# Altium schematic sheet Analog Out Amplifiers PWM Rev 5.SchDoc("Analog Out Amplifiers PWM Rev 5")
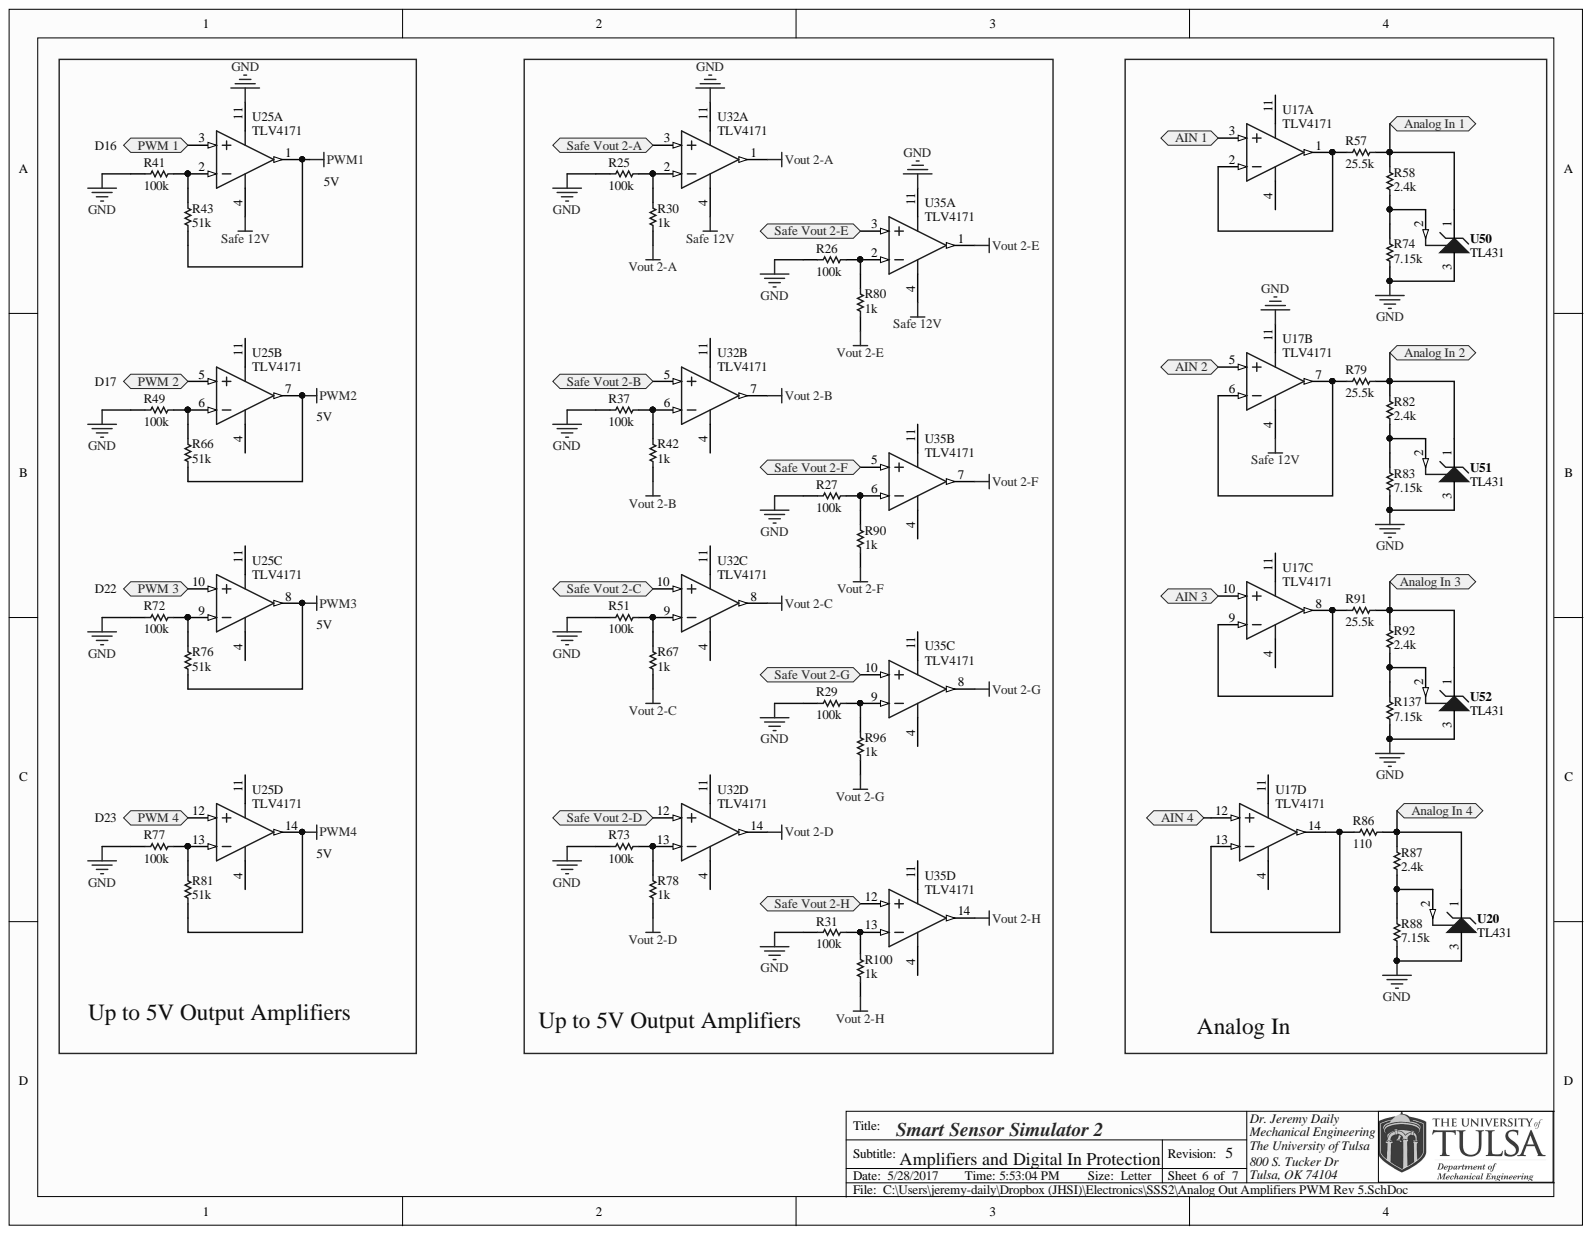
[diagram p0: Analog Out Amplifiers PWM Rev 5.SchDoc]

## Parts
R25  100k  [0603]
R26  100k  [0603]
R27  100k  [0603]
R29  100k  [0603]
R30  1k  [0603]
R31  100k  [0603]
R37  100k  [0603]
R41  100k  [0603]
R42  1k  [0603]
R43  51k  [0603]
R49  100k  [0603]
R51  100k  [0603]
R57  25.5k  [0603]
R58  2.4k  [0603]
R66  51k  [0603]
R67  1k  [0603]
R72  100k  [0603]
R73  100k  [0603]
R74  7.15k  [0603]
R76  51k  [0603]
R77  100k  [0603]
R78  1k  [0603]
R79  25.5k  [0603]
R80  1k  [0603]
R81  51k  [0603]
R82  2.4k  [0603]
R83  7.15k  [0603]
R86  110  [0603]
R87  2.4k  [0603]
R88  7.15k  [0603]
R90  1k  [0603]
R91  25.5k  [0603]
R92  2.4k  [0603]
R96  1k  [0603]
R100  1k  [0603]
R137  7.15k  [0603]
U17  TLV4171  [TI-PW14_M]  (parts A,B,C,D)
U20  TL431  [TI-DBZ3_N]
U25  TLV4171  [TI-PW14_M]  (parts A,B,C,D)
U32  TLV4171  [TI-PW14_M]  (parts A,B,C,D)
U35  TLV4171  [TI-PW14_M]  (parts A,B,C,D)
U50  TL431  [TI-DBZ3_N]
U51  TL431  [TI-DBZ3_N]
U52  TL431  [TI-DBZ3_N]

## Ports
AIN 1, AIN 2, AIN 3, AIN 4, ANALOG IN 1, ANALOG IN 2, ANALOG IN 3, ANALOG IN 4, PWM 1, PWM 2, PWM 3, PWM 4, SAFE VOUT 2-A, SAFE VOUT 2-B, SAFE VOUT 2-C, SAFE VOUT 2-D, SAFE VOUT 2-E, SAFE VOUT 2-F, SAFE VOUT 2-G, SAFE VOUT 2-H

## Nets
GND: R25.2 R26.2 R27.2 R29.2 R31.2 R37.2 R41.2 R49.2 R51.2 R72.2 R73.2 R74.1 R77.2 R83.1 R88.1 R137.1 U17.11 U20.3 U25.11 U32.11 U35.11 U50.3 U51.3 U52.3
PWM1: R43.2 U25.1
PWM2: R66.2 U25.7
PWM3: R76.2 U25.8
PWM4: R81.2 U25.14
SAFE 12V: U17.4 U25.4 U32.4 U35.4
VOUT 2-A: R30.2 U32.1
VOUT 2-B: R42.2 U32.7
VOUT 2-C: R67.2 U32.8
VOUT 2-D: R78.2 U32.14
VOUT 2-E: R80.2 U35.1
VOUT 2-F: R90.2 U35.7
VOUT 2-G: R96.2 U35.8
VOUT 2-H: R100.2 U35.14
AIN 1: U17.3 ; port AIN 1
AIN 2: U17.5 ; port AIN 2
AIN 3: U17.10 ; port AIN 3
AIN 4: U17.12 ; port AIN 4
PWM 1: U25.3 ; port PWM 1
PWM 2: U25.5 ; port PWM 2
PWM 3: U25.10 ; port PWM 3
PWM 4: U25.12 ; port PWM 4
NetR25_1: R25.1 R30.1 U32.2
NetR26_1: R26.1 R80.1 U35.2
NetR27_1: R27.1 R90.1 U35.6
NetR29_1: R29.1 R96.1 U35.9
NetR31_1: R31.1 R100.1 U35.13
NetR37_1: R37.1 R42.1 U32.6
NetR41_1: R41.1 R43.1 U25.2
NetR49_1: R49.1 R66.1 U25.6
NetR51_1: R51.1 R67.1 U32.9
NetR57_1: R57.1 U17.1 U17.2
NetR57_2: R57.2 R58.2 U50.1 ; port ANALOG IN 1
NetR58_1: R58.1 R74.2 U50.2
NetR72_1: R72.1 R76.1 U25.9
NetR73_1: R73.1 R78.1 U32.13
NetR77_1: R77.1 R81.1 U25.13
NetR79_1: R79.1 U17.6 U17.7
NetR79_2: R79.2 R82.2 U51.1 ; port ANALOG IN 2
NetR82_1: R82.1 R83.2 U51.2
NetR86_1: R86.1 U17.13 U17.14
NetR86_2: R86.2 R87.2 U20.1 ; port ANALOG IN 4
NetR87_1: R87.1 R88.2 U20.2
NetR91_1: R91.1 U17.8 U17.9
NetR91_2: R91.2 R92.2 U52.1 ; port ANALOG IN 3
NetR92_1: R92.1 R137.2 U52.2
NetU32_3: U32.3 ; port SAFE VOUT 2-A
NetU32_5: U32.5 ; port SAFE VOUT 2-B
NetU32_10: U32.10 ; port SAFE VOUT 2-C
NetU32_12: U32.12 ; port SAFE VOUT 2-D
NetU35_3: U35.3 ; port SAFE VOUT 2-E
NetU35_5: U35.5 ; port SAFE VOUT 2-F
NetU35_10: U35.10 ; port SAFE VOUT 2-G
NetU35_12: U35.12 ; port SAFE VOUT 2-H

## Library data of the parts
R25-R27 R29 R31 R37 R41 R49 R51 R72 R73 R77 = Chip Resistor: RES SMD 100K OHM 1% 1/10W 0603; Manufacturer: Panasonic Electronic Components; PartNumber: ERJ-3EKF1003V; Component Kind: Standard; Library Name: SSS Integrated Library.IntLib; Supplier 1: Digi-Key; Supplier Part Number 1: P100KHCT-ND
R30 R42 R67 R78 R80 R90 R96 R100 = Chip Resistor: RES SMD 1K OHM 1% 1/10W 0603; Manufacturer: Panasonic Electronic Components; PartNumber: ERJ-3EKF1001V; Component Kind: Standard; Library Name: SSS Schematic Library.SchLib; Supplier 1: Digi-Key; Supplier Part Number 1: P1.00KHCT-ND
R43 R66 R76 R81 = Chip Resistor: RES SMD 51K OHM 1% 1/10W 0603; Manufacturer: Panasonic Electronic Components; PartNumber: ERJ-3EKF5102V; Component Kind: Standard; Library Name: SSS Integrated Library.IntLib; Supplier 1: Digi-Key; Supplier Part Number 1: P51.0KHCT-ND
R57 R79 R91 = Chip Resistor: RES SMD 25.5K OHM 1% 1/10W 0603; Manufacturer: Panasonic Electronic Components; PartNumber: ERJ-3EKF2552V; Component Kind: Standard; Library Name: SSS Integrated Library.IntLib; Supplier 1: Digi-Key; Supplier Part Number 1: P25.5KHCT-ND
R58 R82 R87 R92 = Chip Resistor: RES SMD 2.4K OHM 1% 1/10W 0603; Manufacturer: Panasonic Electronic Components; PartNumber: ERJ-3EKF2401V; Component Kind: Standard; Library Name: SSS Integrated Library.IntLib; Supplier 1: Digi-Key; Supplier Part Number 1: P2.40KHCT-ND
R74 R83 R88 R137 = Chip Resistor: RES SMD 7.15K OHM 1% 1/10W 0603; Manufacturer: Panasonic Electronic Components; PartNumber: ERJ-3EKF7151V; Component Kind: Standard; Library Name: SSS Integrated Library.IntLib; Supplier 1: Digi-Key; Supplier Part Number 1: P7.15KHCT-ND
R86 = Chip Resistor: RES SMD 110 OHM 1% 1/10W 0603; Manufacturer: Panasonic Electronic Components; PartNumber: ERJ-3EKF1100V; Component Kind: Standard; Library Name: SSS Integrated Library.IntLib; Supplier 1: Digi-Key; Supplier Part Number 1: P110HCT-ND
U17 U25 U32 U35 = TL064CPW: Quad Low-Power JFET-Input General-Purpose Operational Amplifier, 7 to 36 V, 0 to 70 degC, 14-pin SOP (PW14), Green (RoHS & no Sb/Br); Manufacturer: Texas Instruments; PartNumber: TLV4171IPWR; Component Kind: Standard; Library Name: TI Amplifiers and Linear Operational Amplifier General Purpose.IntLib; Supplier 1: Digi-Key; Supplier Part Number 1: 296-44866-1-ND
U20 U50-U52 = TL431AIDBZR: Adjustable Precision Shunt Regulator, 34 ppm / degC, 100 mA, -40 to 85 degC, 3-pin SOT-23 (DBZ), Green (RoHS & no Sb/Br); Manufacturer: Texas Instruments; Component Kind: Standard; Library Name: TI Power Management Shunt Voltage Reference.IntLib; PartNumber: TL431AIDBZR; Supplier 1: Digi-Key; Supplier Part Number 1: 296-17329-2-ND
